# Altium schematic sheet TLV3501.SchDoc(TLV3501)
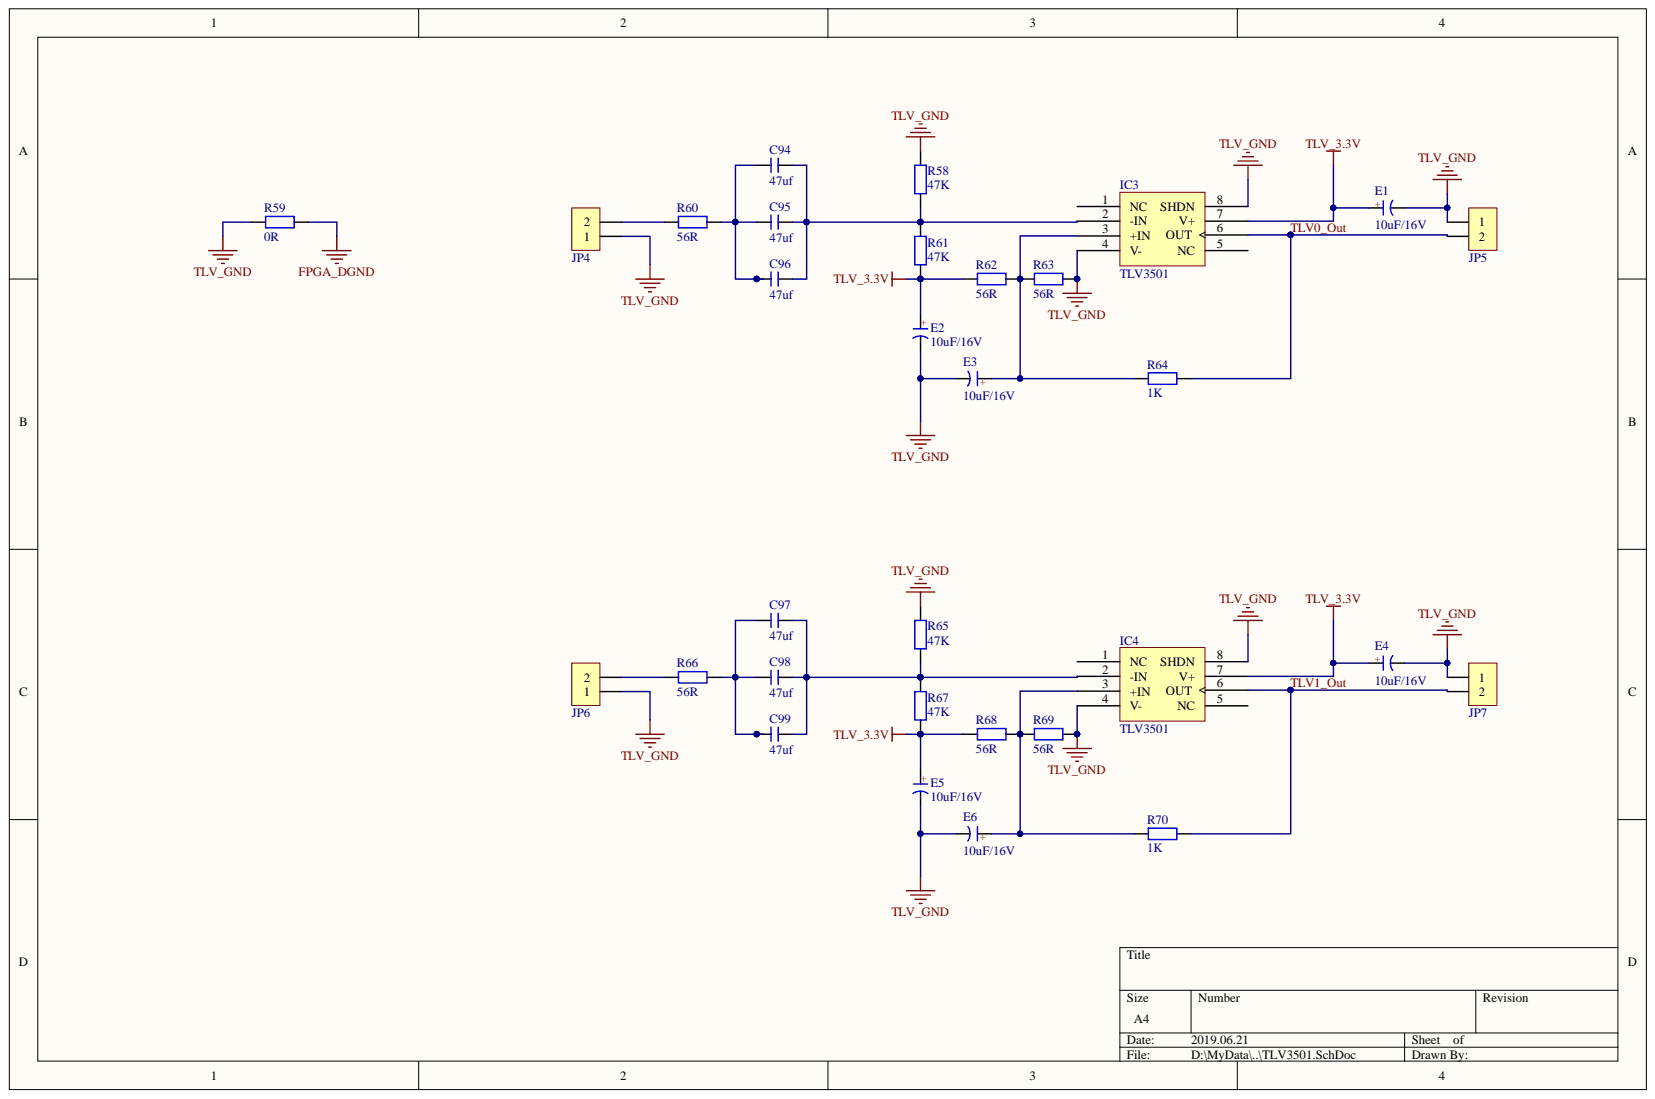
[diagram p0: TLV3501.SchDoc]

## Parts
C94  47uf  [C 0805_M]
C95  47uf  [C 0805_M]
C96  47uf  [C 0805_M]
C97  47uf  [C 0805_M]
C98  47uf  [C 0805_M]
C99  47uf  [C 0805_M]
E1  10uF/16V  [CAP 1.5*4*8]
E2  10uF/16V  [CAP 1.5*4*8]
E3  10uF/16V  [CAP 1.5*4*8]
E4  10uF/16V  [CAP 1.5*4*8]
E5  10uF/16V  [CAP 1.5*4*8]
E6  10uF/16V  [CAP 1.5*4*8]
IC3  TLV3501  [SOP8_M]
IC4  TLV3501  [SOP8_M]
JP4  HDR-1X2  [HDR2.54-LI-2P]  (value not drawn)
JP5  HDR-1X2  [HDR2.54-LI-2P]  (value not drawn)
JP6  HDR-1X2  [HDR2.54-LI-2P]  (value not drawn)
JP7  HDR-1X2  [HDR2.54-LI-2P]  (value not drawn)
R58  47K  [R 0805_M]
R59  0R  [R 0805_M]
R60  56R  [R 0805_M]
R61  47K  [R 0805_M]
R62  56R  [R 0805_M]
R63  56R  [R 0805_M]
R64  1K  [R 0805_M]
R65  47K  [R 0805_M]
R66  56R  [R 0805_M]
R67  47K  [R 0805_M]
R68  56R  [R 0805_M]
R69  56R  [R 0805_M]
R70  1K  [R 0805_M]

## Nets
FPGA_DGND: R59.1
TLV_3.3V: E1.1 E2.1 E4.1 E5.1 IC3.7(V+) IC4.7(V+) R61.1 R62.2 R67.1 R68.2
TLV_GND: E1.2 E2.2 E3.2 E4.2 E5.2 E6.2 IC3.4(V-) IC3.8(SHDN) IC4.4(V-) IC4.8(SHDN) JP4.1 JP5.1 JP6.1 JP7.1 R58.2 R59.2 R63.1 R65.2 R69.1
TLV0_OUT: IC3.6(OUT) JP5.2 R64.1
TLV1_OUT: IC4.6(OUT) JP7.2 R70.1
NetC94_1: C94.1 C95.1 C96.1 R60.1
NetC94_2: C94.2 C95.2 C96.2 IC3.2(-IN) R58.1 R61.2
NetC97_1: C97.1 C98.1 C99.1 R66.1
NetC97_2: C97.2 C98.2 C99.2 IC4.2(-IN) R65.1 R67.2
NetE3_1: E3.1 IC3.3(+IN) R62.1 R63.2 R64.2
NetE6_1: E6.1 IC4.3(+IN) R68.1 R69.2 R70.2
NetJP4_2: JP4.2 R60.2
NetJP6_2: JP6.2 R66.2

Not connected: IC3 1(NC) 5(NC); IC4 1(NC) 5(NC)

## Library data of the parts
C94-C99 = C: 无极性贴片电容; 版权归属: 源创客; BOM名称: 无极性贴片电容; Component Kind: Standard; Library Name: 3.1   - 电阻-电容-电感.SchLib; 学习资源: www.ooocke.com
E1-E6 = C-CAP: 直插电解电容; 版权归属: 源创客; BOM名称: 直插电解电容; Component Kind: Standard; Library Name: 3.1   - 电阻-电容-电感.SchLib; 学习资源: www.ooocke.com
IC3 IC4 = 24Cxx: 外置EEPROM; 版权归属: 源创客; BOM名称: 外置EEPROM; Component Kind: Standard; Library Name: 1.1   - IC芯片.SchLib; 学习资源: www.ooocke.com
JP4-JP7 = Header 2: 2P接插件; 版权归属: 源创客; BOM名称: 2P接插件; Component Kind: Standard; Library Name: 4.5   - 接插件-脚距2.54.SchLib; 学习资源: www.ooocke.com
R58-R70 = R: 贴片电阻; 版权归属: 源创客; BOM名称: 贴片电阻; Component Kind: Standard; Library Name: 3.1   - 电阻-电容-电感.SchLib; 学习资源: www.ooocke.com
